# Altium schematic sheet [05] - FLASH MEMORY INTERFACE.SchDoc
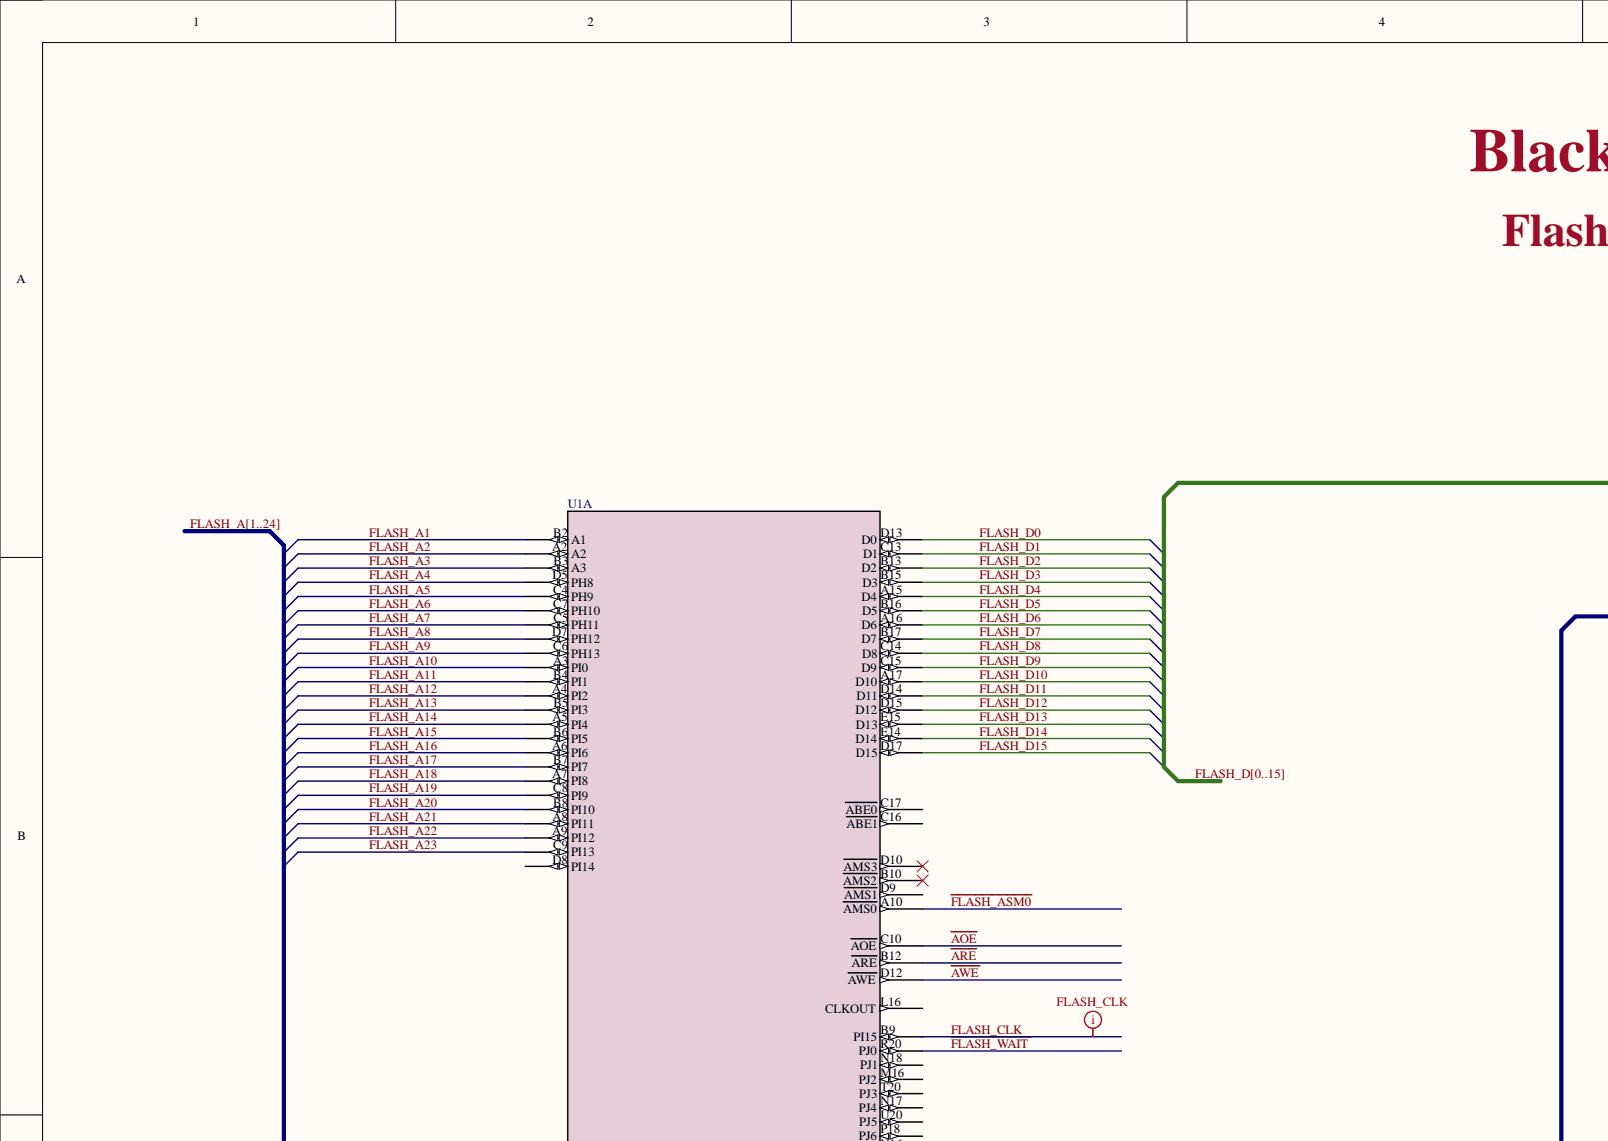
[diagram p0: [05] - FLASH MEMORY INTERFACE.SchDoc, top left]
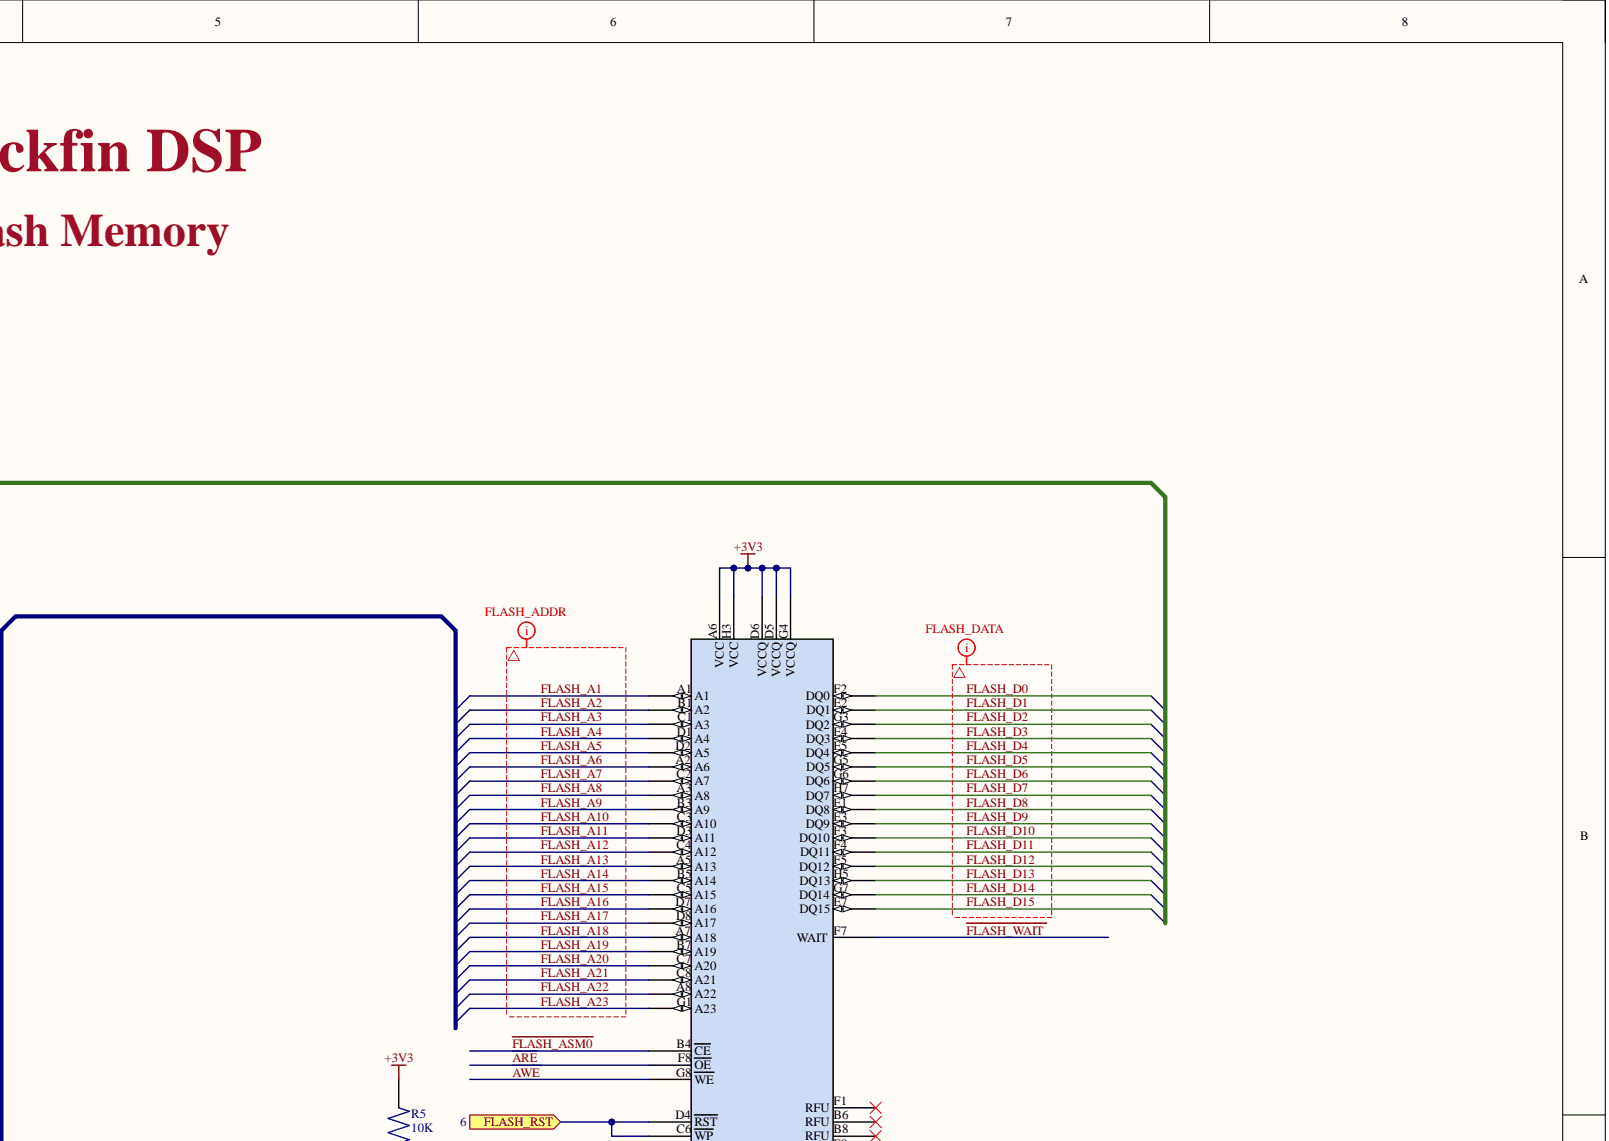
[diagram p1: [05] - FLASH MEMORY INTERFACE.SchDoc, top right]
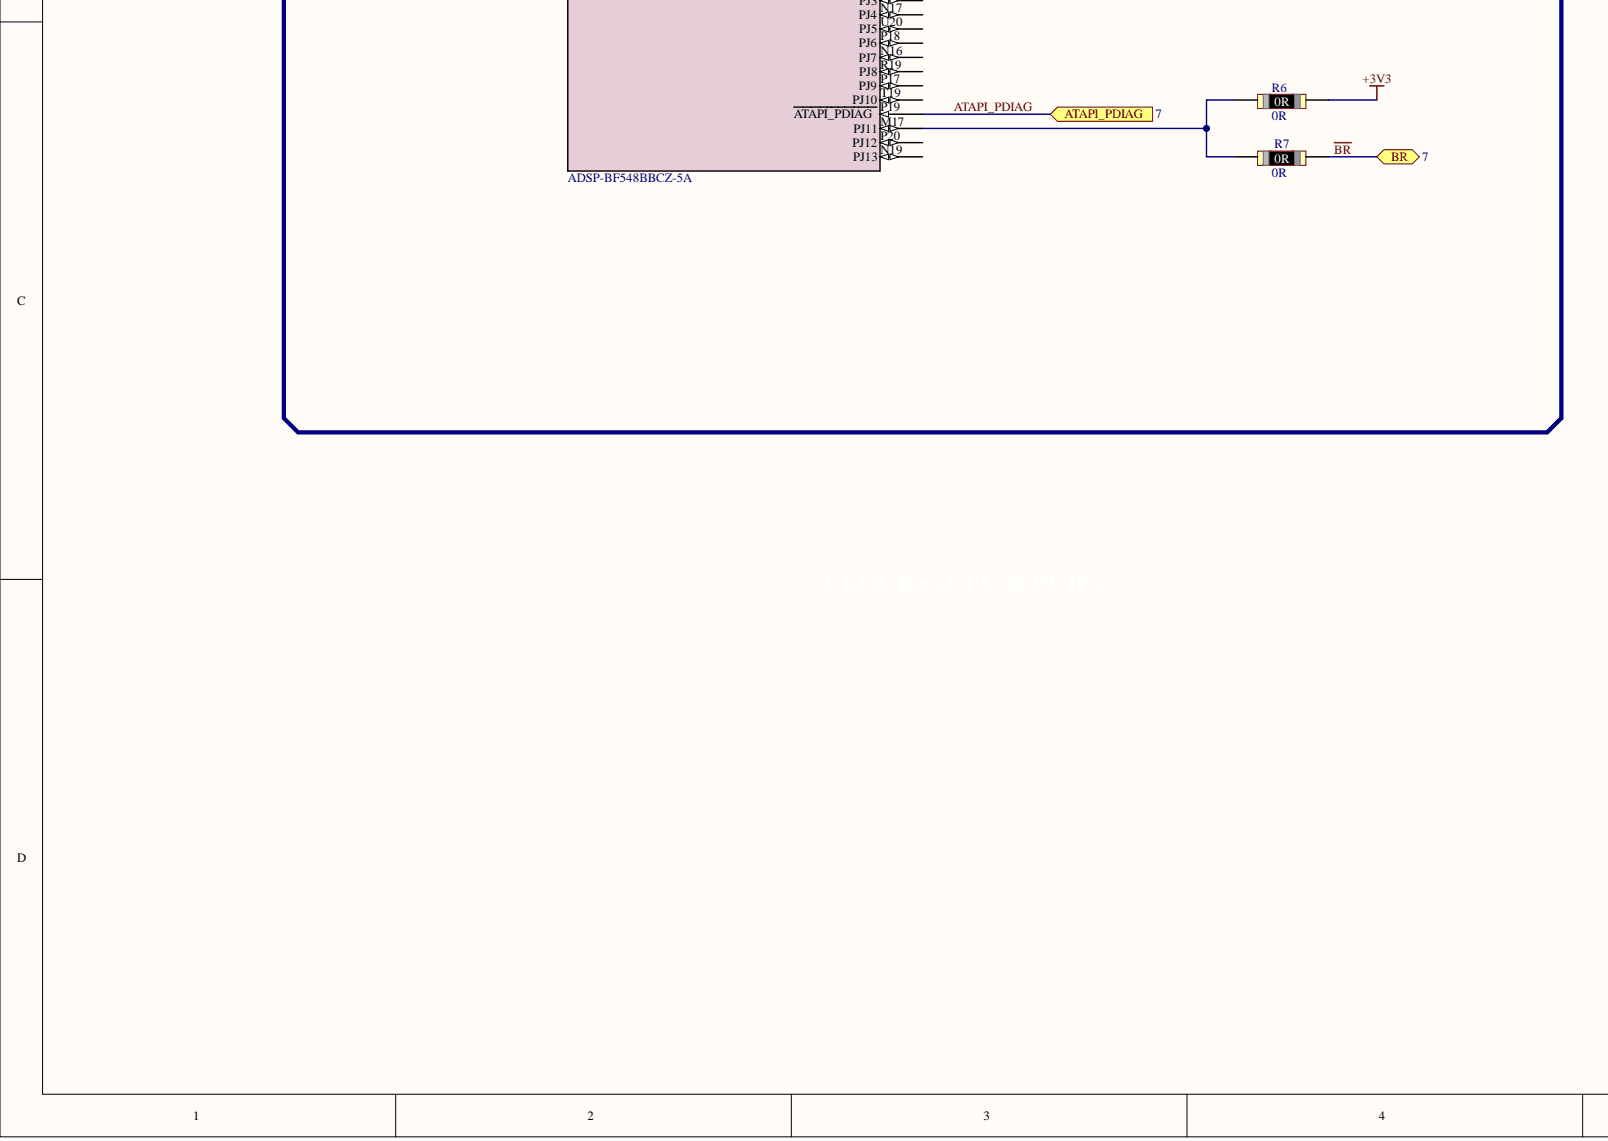
[diagram p2: [05] - FLASH MEMORY INTERFACE.SchDoc, bottom left]
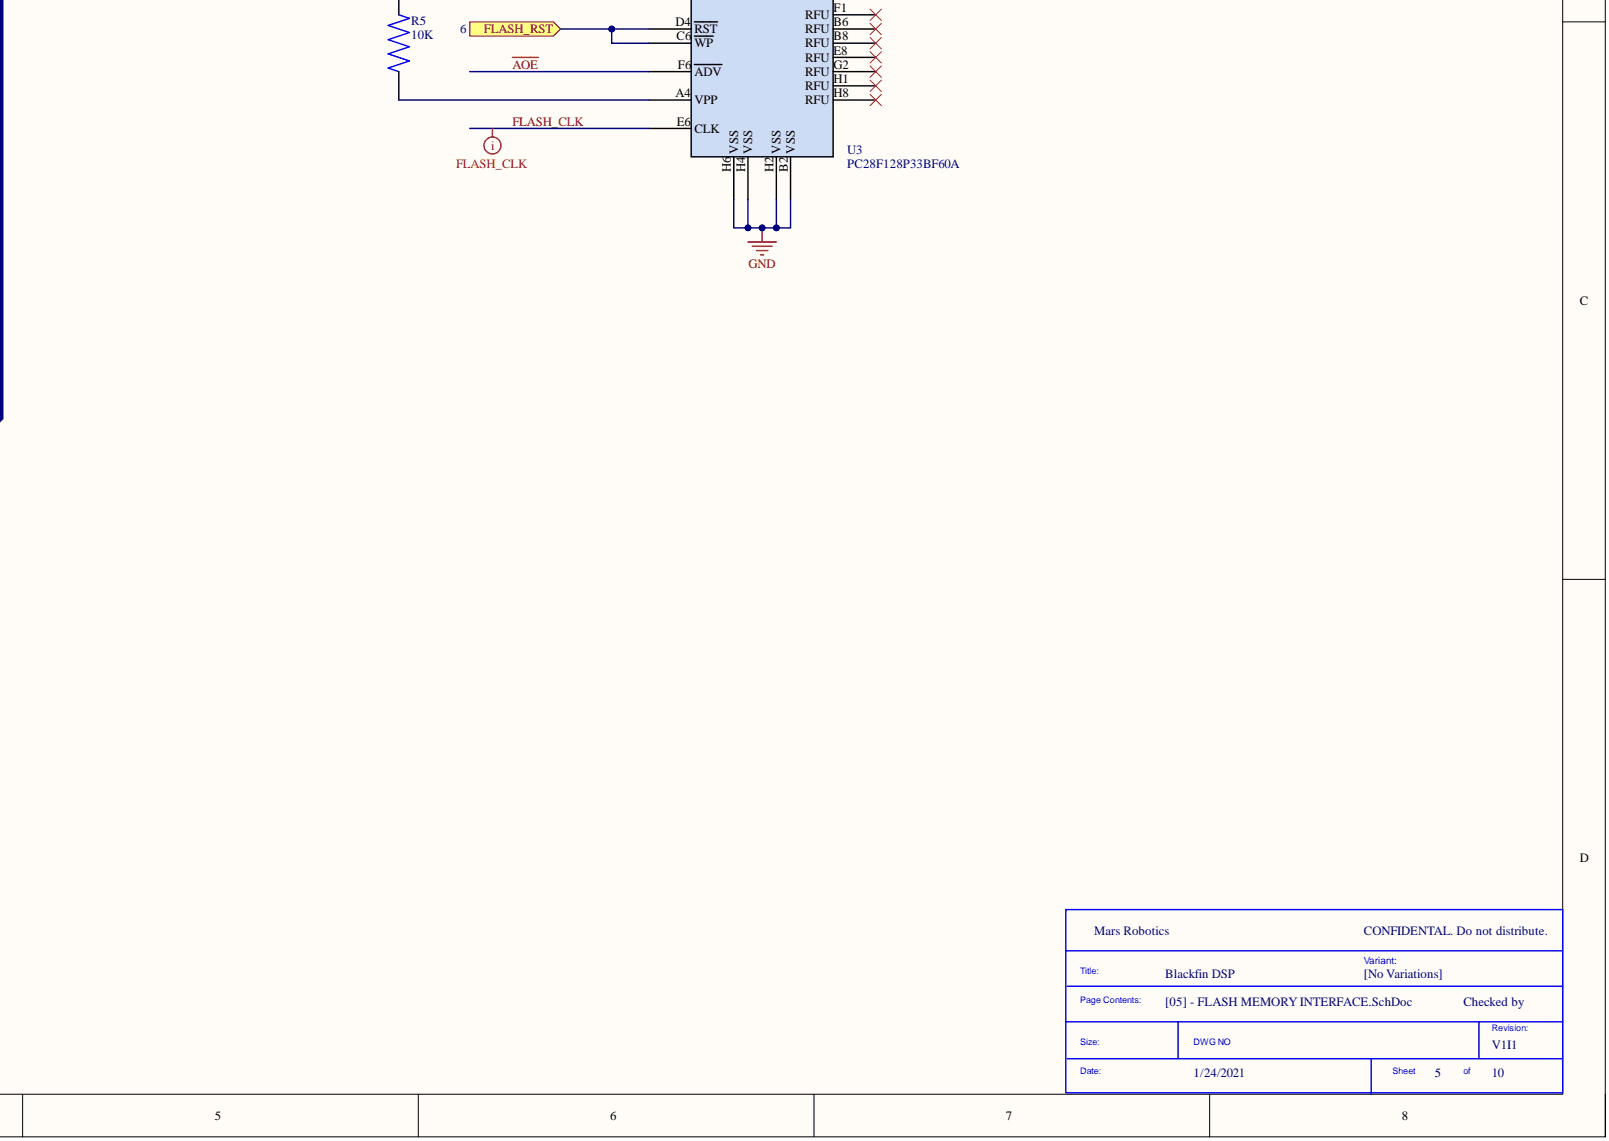
[diagram p3: [05] - FLASH MEMORY INTERFACE.SchDoc, bottom right]

## Parts
R5  10K  [RES0402]
R6  0R  [RES0603]
R7  0R  [RES0603]
U1  ADSP-BF548BBCZ-5A  [BC_400_1_ADI]  (part A)
U3  PC28F128P33BF60A  [BGA64_8X10_MRN]

## Ports
ATAPI_PDIAG, BR, FLASH_RST

## Nets
+3V3: R5.2 R6.2 U3.A6(VCC) U3.D5(VCCQ) U3.D6(VCCQ) U3.G4(VCCQ) U3.H3(VCC)
GND: U3.B2(VSS) U3.H2(VSS) U3.H4(VSS) U3.H6(VSS)
~{AOE}: U1.C10(AOE) U3.F6(ADV)
~{ARE}: U1.B12(ARE) U3.F8(OE)
~{AWE}: U1.D12(AWE) U3.G8(WE)
ATAPI_PDIAG: U1.P19(ATAPI_PDIAG) ; port ATAPI_PDIAG
~{BR}: R7.2 ; port BR
~{FLASH_ASM0}: U1.A10(AMS0) U3.B4(CE)
~{FLASH_WAIT}: U1.R20(PJ0) U3.F7(WAIT)
FLASH_A1: U1.B2(A1) U3.A1  [also labelled FLASH_A]
FLASH_A2: U1.A2 U3.B1(A2)  [also labelled FLASH_A]
FLASH_A3: U1.B3(A3) U3.C1(A3)  [also labelled FLASH_A]
FLASH_A4: U1.D5(PH8) U3.D1(A4)  [also labelled FLASH_A]
FLASH_A5: U1.C4(PH9) U3.D2(A5)  [also labelled FLASH_A]
FLASH_A6: U1.C7(PH10) U3.A2(A6)  [also labelled FLASH_A]
FLASH_A7: U1.C5(PH11) U3.C2(A7)  [also labelled FLASH_A]
FLASH_A8: U1.D7(PH12) U3.A3(A8)  [also labelled FLASH_A]
FLASH_A9: U1.C6(PH13) U3.B3(A9)  [also labelled FLASH_A]
FLASH_A10: U1.A3(PI0) U3.C3(A10)  [also labelled FLASH_A]
FLASH_A11: U1.B4(PI1) U3.D3(A11)  [also labelled FLASH_A]
FLASH_A12: U1.A4(PI2) U3.C4(A12)  [also labelled FLASH_A]
FLASH_A13: U1.B5(PI3) U3.A5(A13)  [also labelled FLASH_A]
FLASH_A14: U1.A5(PI4) U3.B5(A14)  [also labelled FLASH_A]
FLASH_A15: U1.B6(PI5) U3.C5(A15)  [also labelled FLASH_A]
FLASH_A16: U1.A6(PI6) U3.D7(A16)  [also labelled FLASH_A]
FLASH_A17: U1.B7(PI7) U3.D8(A17)  [also labelled FLASH_A]
FLASH_A18: U1.A7(PI8) U3.A7(A18)  [also labelled FLASH_A]
FLASH_A19: U1.C8(PI9) U3.B7(A19)  [also labelled FLASH_A]
FLASH_A20: U1.B8(PI10) U3.C7(A20)  [also labelled FLASH_A]
FLASH_A21: U1.A8(PI11) U3.C8(A21)  [also labelled FLASH_A]
FLASH_A22: U1.A9(PI12) U3.A8(A22)  [also labelled FLASH_A]
FLASH_A23: U1.C9(PI13) U3.G1(A23)  [also labelled FLASH_A]
FLASH_CLK: U1.B9(PI15) U3.E6(CLK)
FLASH_D0: U1.D13(D0) U3.F2(DQ0)  [also labelled FLASH_D]
FLASH_D1: U1.C13(D1) U3.E2(DQ1)  [also labelled FLASH_D]
FLASH_D2: U1.B13(D2) U3.G3(DQ2)  [also labelled FLASH_D]
FLASH_D3: U1.B15(D3) U3.E4(DQ3)  [also labelled FLASH_D]
FLASH_D4: U1.A15(D4) U3.E5(DQ4)  [also labelled FLASH_D]
FLASH_D5: U1.B16(D5) U3.G5(DQ5)  [also labelled FLASH_D]
FLASH_D6: U1.A16(D6) U3.G6(DQ6)  [also labelled FLASH_D]
FLASH_D7: U1.B17(D7) U3.H7(DQ7)  [also labelled FLASH_D]
FLASH_D8: U1.C14(D8) U3.E1(DQ8)  [also labelled FLASH_D]
FLASH_D9: U1.C15(D9) U3.E3(DQ9)  [also labelled FLASH_D]
FLASH_D10: U1.A17(D10) U3.F3(DQ10)  [also labelled FLASH_D]
FLASH_D11: U1.D14(D11) U3.F4(DQ11)  [also labelled FLASH_D]
FLASH_D12: U1.D15(D12) U3.F5(DQ12)  [also labelled FLASH_D]
FLASH_D13: U1.E15(D13) U3.H5(DQ13)  [also labelled FLASH_D]
FLASH_D14: U1.E14(D14) U3.G7(DQ14)  [also labelled FLASH_D]
FLASH_D15: U1.D17(D15) U3.E7(DQ15)  [also labelled FLASH_D]
FLASH_RST: U3.C6(WP) U3.D4(RST) ; port FLASH_RST
NetR5_1: R5.1 U3.A4(VPP)
NetR6_1: R6.1 R7.1 U1.M17(PJ11)
FLASH_A24:  [also labelled FLASH_A]

Not connected: U1 B10(AMS2) C16(ABE1) C17(ABE0) D8(PI14) D9(AMS1) D10(AMS3) L16(CLKOUT) M16(PJ2) N16(PJ7) N17(PJ4) N18(PJ1) N19(PJ13) P17(PJ9) P18(PJ6) P20(PJ12) R19(PJ8) T19(PJ10) T20(PJ3) U20(PJ5); U3 B6(RFU) B8(RFU) E8(RFU) F1(RFU) G2(RFU) H1(RFU) H8(RFU)

## Library data of the parts
R5 = CRCW040210K0FHEDP: 10 kOhms ±1% 0.063W, 1/16W Chip Resistor 0402 (1005 Metric) Automotive AEC-Q200 Thick Film; Manufacturer: Vishay Dale; Component Kind: Standard; Digi-Key Part Number: CRCW040210K0FHEDP-ND; Manufacturer Product Number: CRCW040210K0FHEDP; Package / Case: 0402; Resistance: 10K; Supplier: Vishay Dale; Library Name: BlackfinSOM.SchLib
R6 R7 = CR0603AJ/-000EAS: Res Anti-Sulfured Jumper Thick Film 0603 0 Ohm 1/10W Molded Paper T/R; Manufacturer: Bourns; Category: Chip SMD Resistors; Component Kind: Standard; Manufacturer Part Number: CR0603AJ/-000EAS; Pricing 1: 1=0.01100 (USD); RoHS: No; Stock 1: 4944; Supplier 1: Avnet; Library Name: BlackfinSOM.SchLib
U1 = ADSP-BF548BBCZ-5A: IC DSP 16BIT 533MHZ 400CSBGA; Manufacturer: Analog Devices Inc.; Component Kind: Standard; Manufacturer Product Number: ADSP-BF548BBCZ-5A; Manufacturer_Part_Number: ADSP-BF548BBCZ-5A; Supplier: Analog Devices Inc.; TypeDigi-Key Part Number: ADSP-BF548BBCZ-5A-ND; Library Name: BlackfinSOM.SchLib
U3 = PC28F128P33BF60A: FLASH - NOR Memory IC 128Mb (8M x 16) Parallel 52MHz 60ns 64-EasyBGA (10x13); Manufacturer: Alliance Memory, Inc.; Component Kind: Standard; Manufacturer Product Number: PC28F128P33BF60A; Package / Case: 64-TBGA; Series: StrataFlash; Supplier: Alliance Memory, Inc.; Digi-Key Part Number: D:\embedded_systems\Projects\Pievision\BLACKFIN-SOM\Design; Library Name: BlackfinSOM.SchLib
